# Altium schematic sheet [03] - CPU - DDR3, DDR3 MEM.SchDoc("[03] - CPU - DDR3, DDR3 MEM")
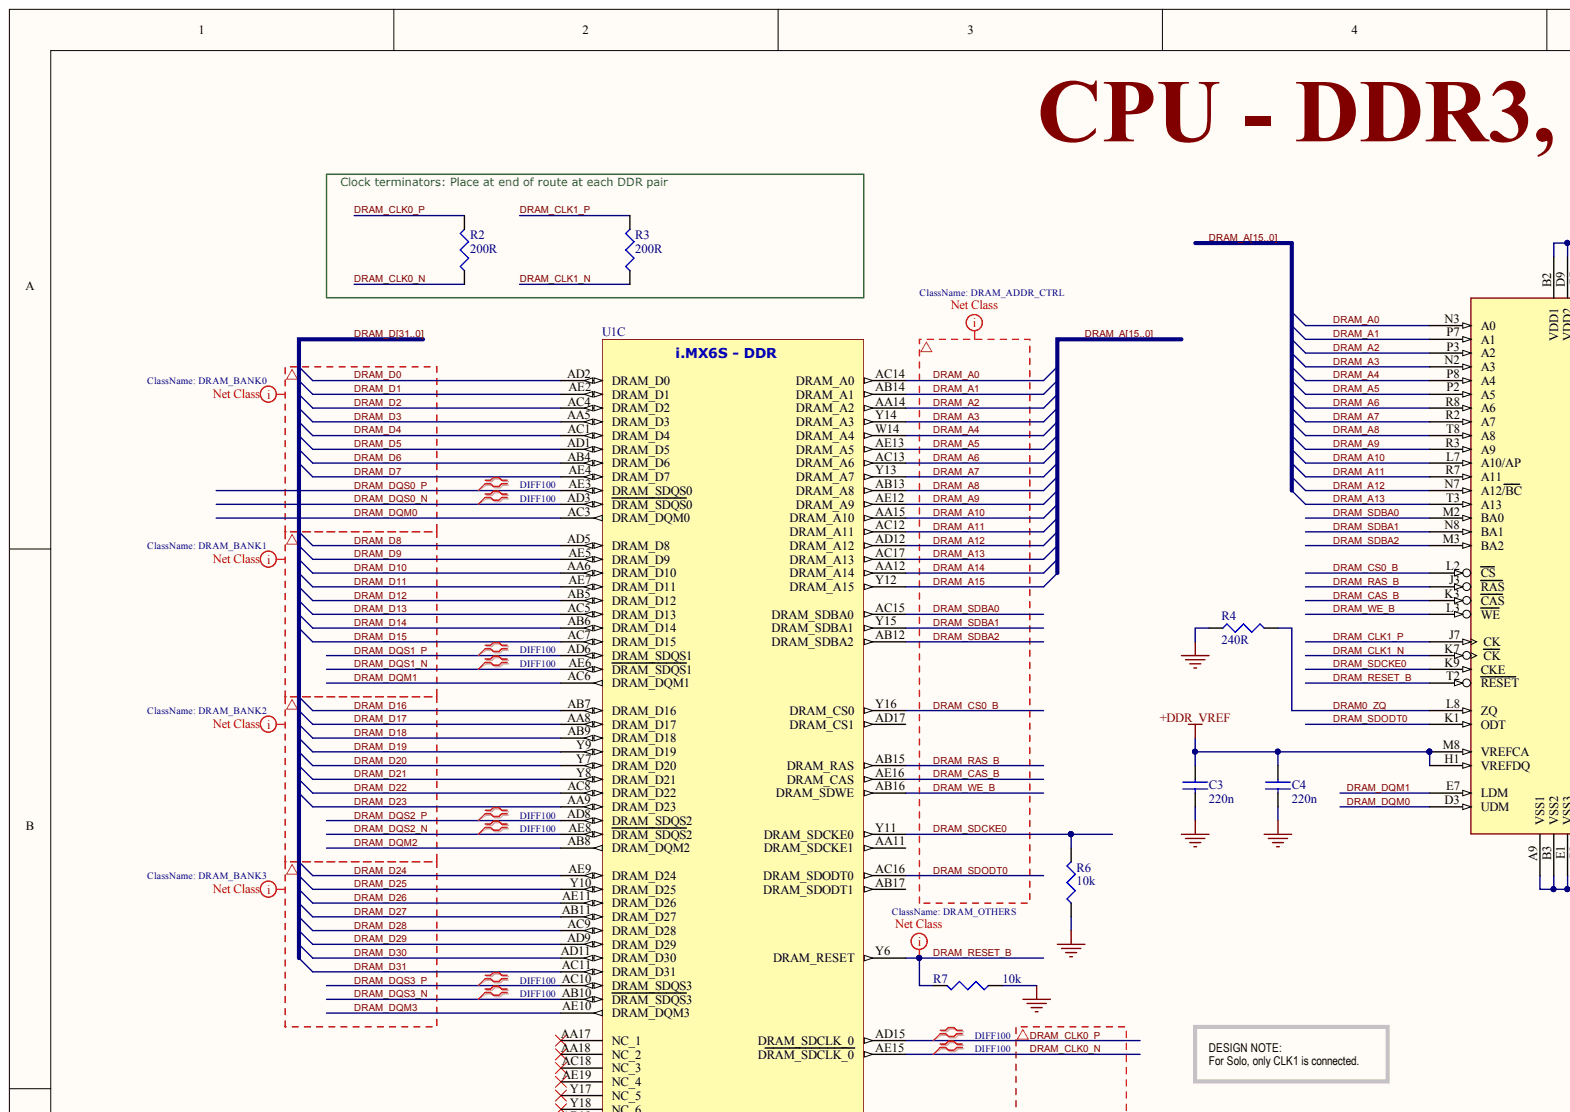
[diagram p0: [03] - CPU - DDR3, DDR3 MEM.SchDoc, top left]
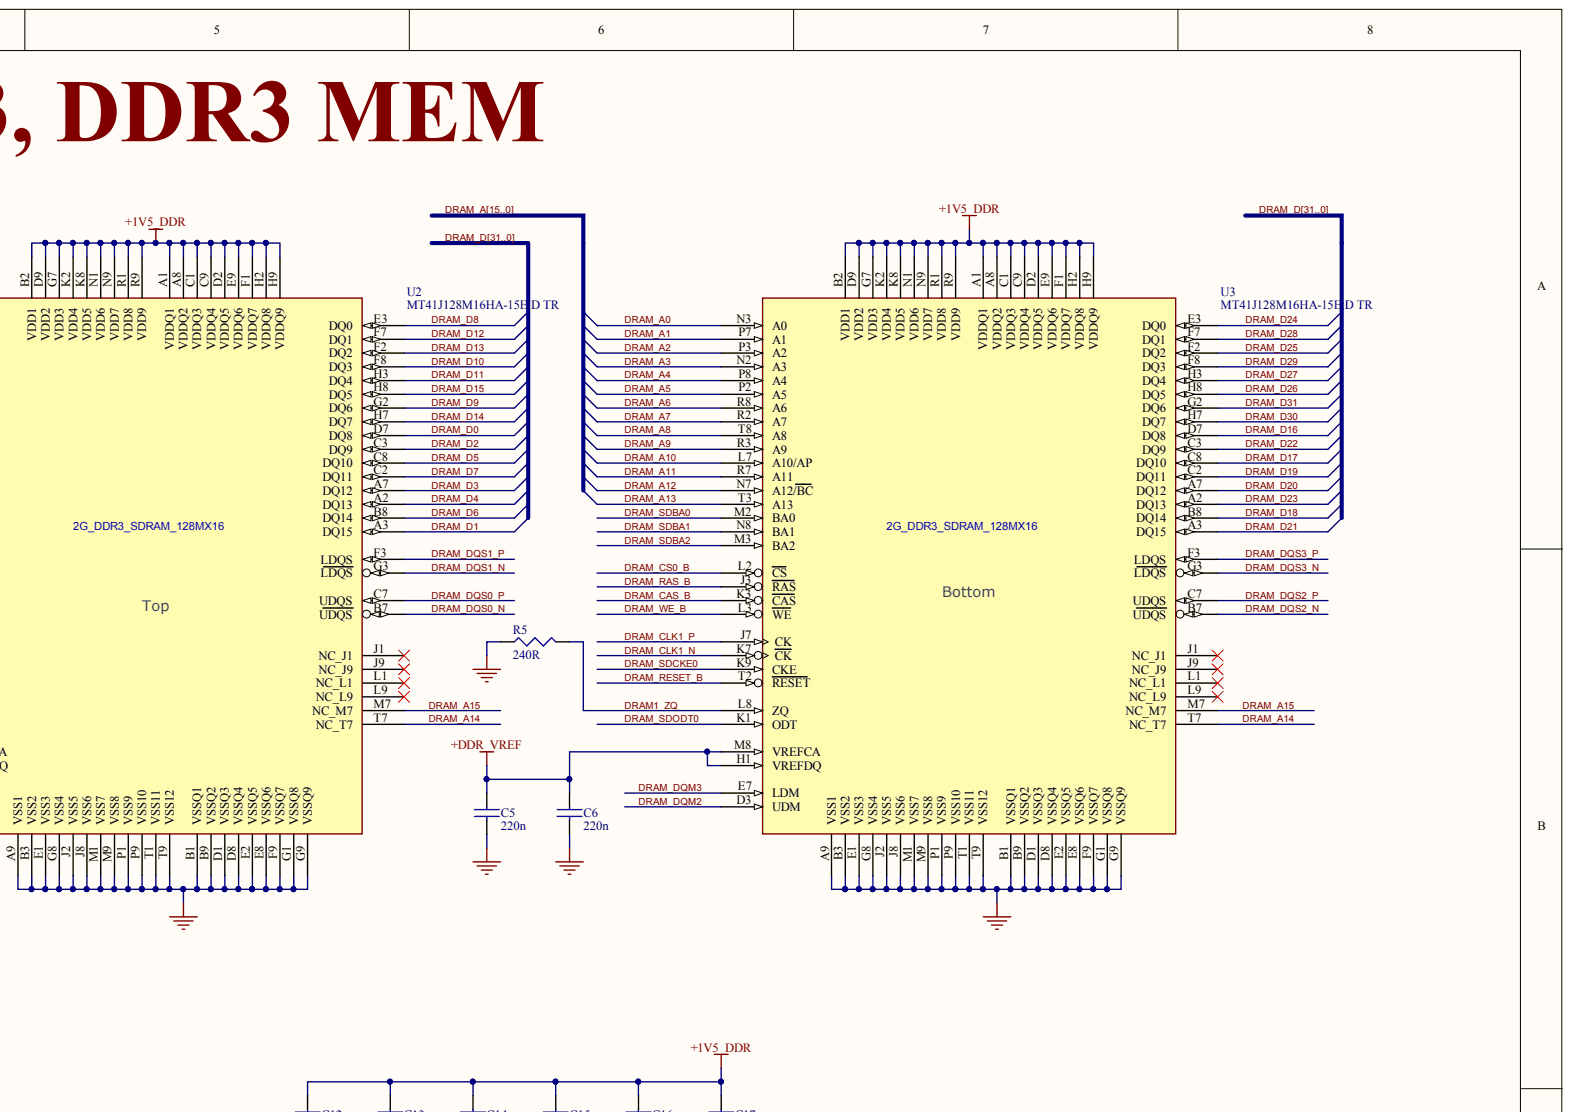
[diagram p1: [03] - CPU - DDR3, DDR3 MEM.SchDoc, top right]
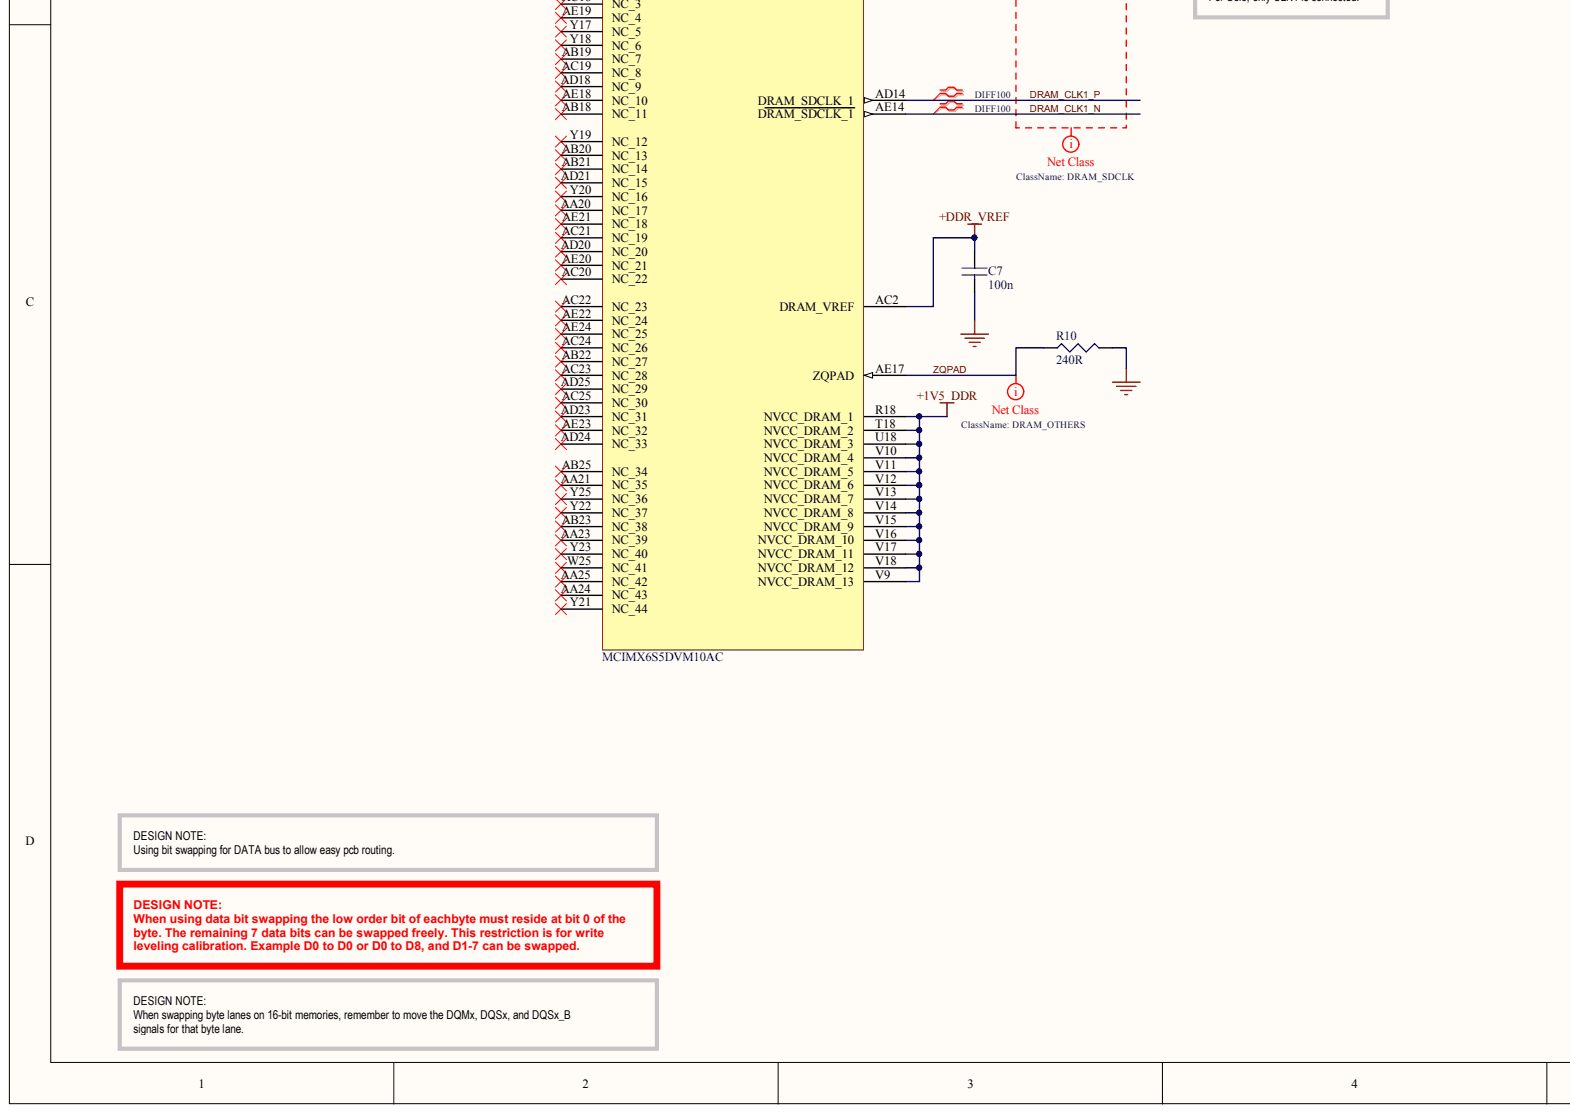
[diagram p2: [03] - CPU - DDR3, DDR3 MEM.SchDoc, bottom left]
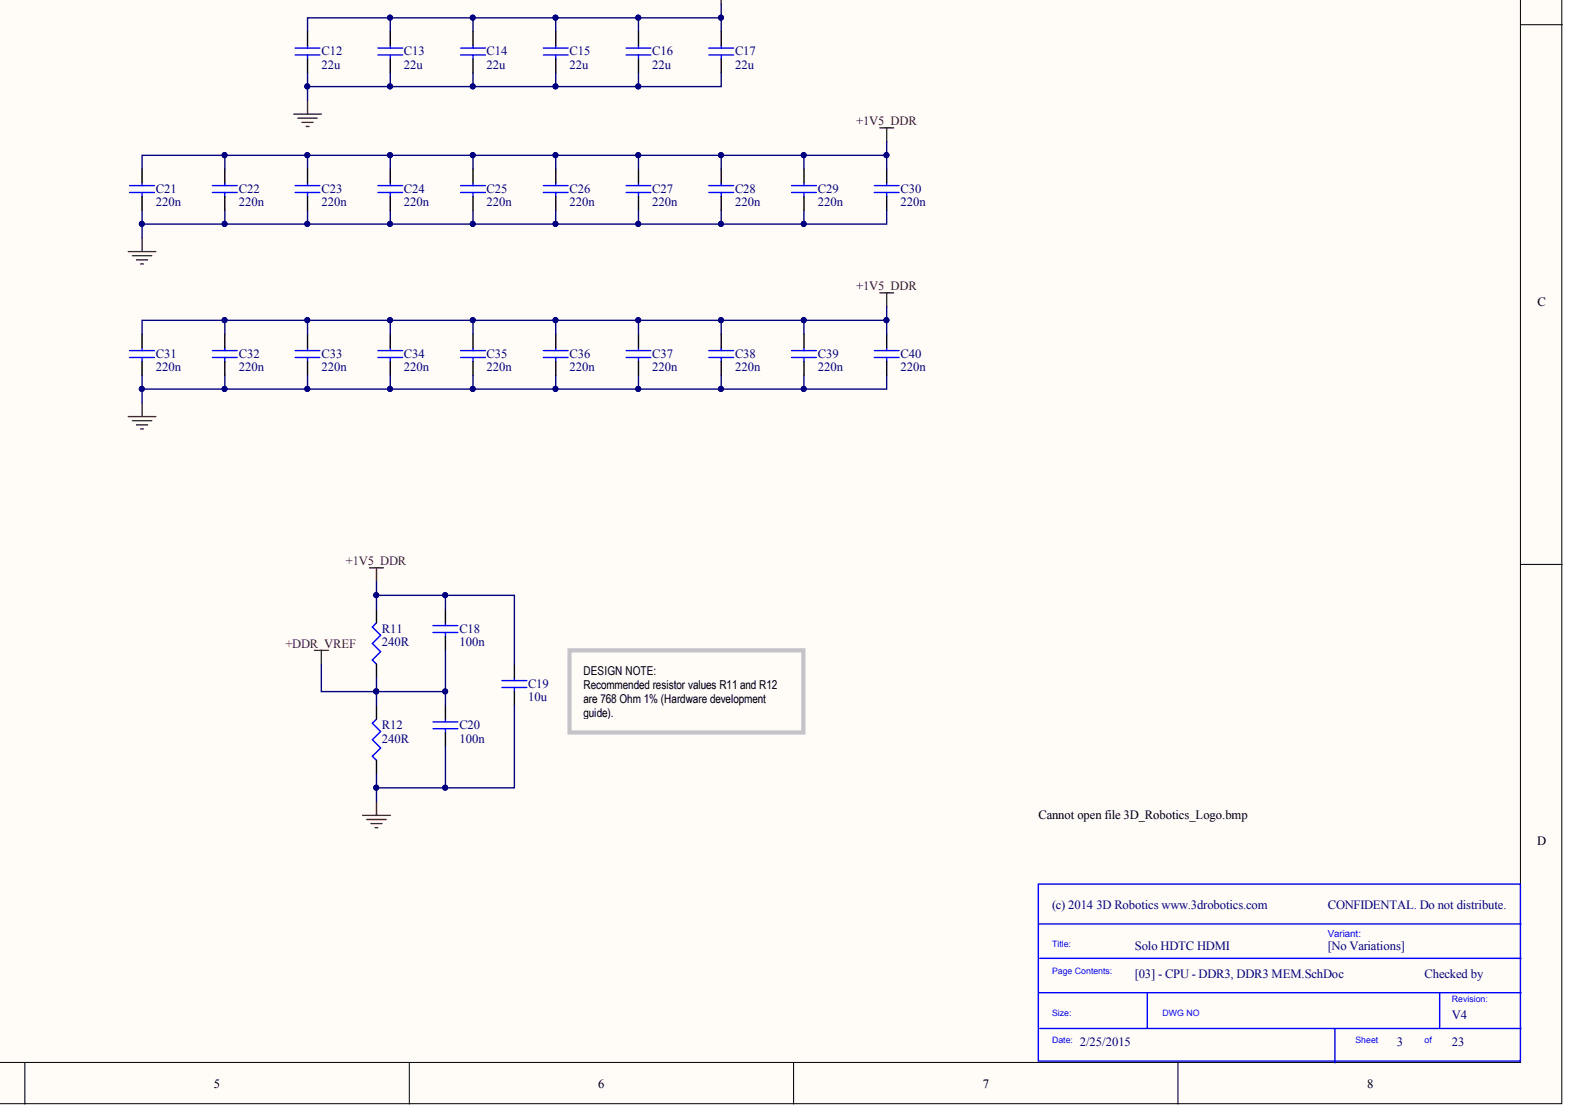
[diagram p3: [03] - CPU - DDR3, DDR3 MEM.SchDoc, bottom right]

## Parts
C3  220n
C4  220n
C5  220n
C6  220n
C7  100n
C12  22u
C13  22u
C14  22u
C15  22u
C16  22u
C17  22u
C18  100n
C19  10u
C20  100n
C21  220n
C22  220n
C23  220n
C24  220n
C25  220n
C26  220n
C27  220n
C28  220n
C29  220n
C30  220n
C31  220n
C32  220n
C33  220n
C34  220n
C35  220n
C36  220n
C37  220n
C38  220n
C39  220n
C40  220n
R2  200R
R3  200R
R4  240R
R5  240R
R6  10k
R7  10k
R10  240R
R11  240R
R12  240R
U1  MCIMX6S5DVM10AC  (part C)
U2  MT41J128M16HA-15E:D TR
U3  MT41J128M16HA-15E:D TR

## Nets
+1V5_DDR: C12.1 C13.1 C14.1 C15.1 C16.1 C17.1 C18.1 C19.1 C21.1 C22.1 C23.1 C24.1 C25.1 C26.1 C27.1 C28.1 C29.1 C30.1 C31.1 C32.1 C33.1 C34.1 C35.1 C36.1 C37.1 C38.1 C39.1 C40.1 R11.2 U1.R18(NVCC_DRAM_1) U1.T18(NVCC_DRAM_2) U1.U18(NVCC_DRAM_3) U1.V9(NVCC_DRAM_13) U1.V10(NVCC_DRAM_4) U1.V11(NVCC_DRAM_5) U1.V12(NVCC_DRAM_6) U1.V13(NVCC_DRAM_7) U1.V14(NVCC_DRAM_8) U1.V15(NVCC_DRAM_9) U1.V16(NVCC_DRAM_10) U1.V17(NVCC_DRAM_11) U1.V18(NVCC_DRAM_12) U2.A1(VDDQ1) U2.A8(VDDQ2) U2.B2(VDD1) U2.C1(VDDQ3) U2.C9(VDDQ4) U2.D2(VDDQ5) U2.D9(VDD2) U2.E9(VDDQ6) U2.F1(VDDQ7) U2.G7(VDD3) U2.H2(VDDQ8) U2.H9(VDDQ9) U2.K2(VDD4) U2.K8(VDD5) U2.N1(VDD6) U2.N9(VDD7) U2.R1(VDD8) U2.R9(VDD9) U3.A1(VDDQ1) U3.A8(VDDQ2) U3.B2(VDD1) U3.C1(VDDQ3) U3.C9(VDDQ4) U3.D2(VDDQ5) U3.D9(VDD2) U3.E9(VDDQ6) U3.F1(VDDQ7) U3.G7(VDD3) U3.H2(VDDQ8) U3.H9(VDDQ9) U3.K2(VDD4) U3.K8(VDD5) U3.N1(VDD6) U3.N9(VDD7) U3.R1(VDD8) U3.R9(VDD9)
+DDR_VREF: C3.1 C4.1 C5.1 C6.1 C7.1 C18.2 C20.1 R11.1 R12.2 U1.AC2(DRAM_VREF) U2.H1(VREFDQ) U2.M8(VREFCA) U3.H1(VREFDQ) U3.M8(VREFCA)
GND: C3.2 C4.2 C5.2 C6.2 C7.2 C12.2 C13.2 C14.2 C15.2 C16.2 C17.2 C19.2 C20.2 C21.2 C22.2 C23.2 C24.2 C25.2 C26.2 C27.2 C28.2 C29.2 C30.2 C31.2 C32.2 C33.2 C34.2 C35.2 C36.2 C37.2 C38.2 C39.2 C40.2 R4.1 R5.1 R6.1 R7.1 R10.2 R12.1 U2.A9(VSS1) U2.B1(VSSQ1) U2.B3(VSS2) U2.B9(VSSQ2) U2.D1(VSSQ3) U2.D8(VSSQ4) U2.E1(VSS3) U2.E2(VSSQ5) U2.E8(VSSQ6) U2.F9(VSSQ7) U2.G1(VSSQ8) U2.G8(VSS4) U2.G9(VSSQ9) U2.J2(VSS5) U2.J8(VSS6) U2.M1(VSS7) U2.M9(VSS8) U2.P1(VSS9) U2.P9(VSS10) U2.T1(VSS11) U2.T9(VSS12) U3.A9(VSS1) U3.B1(VSSQ1) U3.B3(VSS2) U3.B9(VSSQ2) U3.D1(VSSQ3) U3.D8(VSSQ4) U3.E1(VSS3) U3.E2(VSSQ5) U3.E8(VSSQ6) U3.F9(VSSQ7) U3.G1(VSSQ8) U3.G8(VSS4) U3.G9(VSSQ9) U3.J2(VSS5) U3.J8(VSS6) U3.M1(VSS7) U3.M9(VSS8) U3.P1(VSS9) U3.P9(VSS10) U3.T1(VSS11) U3.T9(VSS12)
DRAM0_ZQ: R4.2 U2.L8(ZQ)
DRAM1_ZQ: R5.2 U3.L8(ZQ)
DRAM_A0: U1.AC14(DRAM_A0) U2.N3(A0) U3.N3(A0)  [also labelled DRAM_A]
DRAM_A1: U1.AB14(DRAM_A1) U2.P7(A1) U3.P7(A1)  [also labelled DRAM_A]
DRAM_A2: U1.AA14(DRAM_A2) U2.P3(A2) U3.P3(A2)  [also labelled DRAM_A]
DRAM_A3: U1.Y14(DRAM_A3) U2.N2(A3) U3.N2(A3)  [also labelled DRAM_A]
DRAM_A4: U1.W14(DRAM_A4) U2.P8(A4) U3.P8(A4)  [also labelled DRAM_A]
DRAM_A5: U1.AE13(DRAM_A5) U2.P2(A5) U3.P2(A5)  [also labelled DRAM_A]
DRAM_A6: U1.AC13(DRAM_A6) U2.R8(A6) U3.R8(A6)  [also labelled DRAM_A]
DRAM_A7: U1.Y13(DRAM_A7) U2.R2(A7) U3.R2(A7)  [also labelled DRAM_A]
DRAM_A8: U1.AB13(DRAM_A8) U2.T8(A8) U3.T8(A8)  [also labelled DRAM_A]
DRAM_A9: U1.AE12(DRAM_A9) U2.R3(A9) U3.R3(A9)  [also labelled DRAM_A]
DRAM_A10: U1.AA15(DRAM_A10) U2.L7(A10/AP) U3.L7(A10/AP)  [also labelled DRAM_A]
DRAM_A11: U1.AC12(DRAM_A11) U2.R7(A11) U3.R7(A11)  [also labelled DRAM_A]
DRAM_A12: U1.AD12(DRAM_A12) U2.N7(A12/BC) U3.N7(A12/BC)  [also labelled DRAM_A]
DRAM_A13: U1.AC17(DRAM_A13) U2.T3(A13) U3.T3(A13)  [also labelled DRAM_A]
DRAM_A14: U1.AA12(DRAM_A14) U2.T7(NC_T7) U3.T7(NC_T7)  [also labelled DRAM_A]
DRAM_A15: U1.Y12(DRAM_A15) U2.M7(NC_M7) U3.M7(NC_M7)  [also labelled DRAM_A]
DRAM_CAS_B: U1.AE16(DRAM_CAS) U2.K3(CAS) U3.K3(CAS)
DRAM_CLK0_N: R2.1 U1.AE15(DRAM_SDCLK_0)
DRAM_CLK0_P: R2.2 U1.AD15(DRAM_SDCLK_0)
DRAM_CLK1_N: R3.1 U1.AE14(DRAM_SDCLK_1) U2.K7(CK) U3.K7(CK)
DRAM_CLK1_P: R3.2 U1.AD14(DRAM_SDCLK_1) U2.J7(CK) U3.J7(CK)
DRAM_CS0_B: U1.Y16(DRAM_CS0) U2.L2(CS) U3.L2(CS)
DRAM_D0: U1.AD2(DRAM_D0) U2.D7(DQ8)  [also labelled DRAM_D]
DRAM_D1: U1.AE2(DRAM_D1) U2.A3(DQ15)  [also labelled DRAM_D]
DRAM_D2: U1.AC4(DRAM_D2) U2.C3(DQ9)  [also labelled DRAM_D]
DRAM_D3: U1.AA5(DRAM_D3) U2.A7(DQ12)  [also labelled DRAM_D]
DRAM_D4: U1.AC1(DRAM_D4) U2.A2(DQ13)  [also labelled DRAM_D]
DRAM_D5: U1.AD1(DRAM_D5) U2.C8(DQ10)  [also labelled DRAM_D]
DRAM_D6: U1.AB4(DRAM_D6) U2.B8(DQ14)  [also labelled DRAM_D]
DRAM_D7: U1.AE4(DRAM_D7) U2.C2(DQ11)  [also labelled DRAM_D]
DRAM_D8: U1.AD5(DRAM_D8) U2.E3(DQ0)  [also labelled DRAM_D]
DRAM_D9: U1.AE5(DRAM_D9) U2.G2(DQ6)  [also labelled DRAM_D]
DRAM_D10: U1.AA6(DRAM_D10) U2.F8(DQ3)  [also labelled DRAM_D]
DRAM_D11: U1.AE7(DRAM_D11) U2.H3(DQ4)  [also labelled DRAM_D]
DRAM_D12: U1.AB5(DRAM_D12) U2.F7(DQ1)  [also labelled DRAM_D]
DRAM_D13: U1.AC5(DRAM_D13) U2.F2(DQ2)  [also labelled DRAM_D]
DRAM_D14: U1.AB6(DRAM_D14) U2.H7(DQ7)  [also labelled DRAM_D]
DRAM_D15: U1.AC7(DRAM_D15) U2.H8(DQ5)  [also labelled DRAM_D]
DRAM_D16: U1.AB7(DRAM_D16) U3.D7(DQ8)  [also labelled DRAM_D]
DRAM_D17: U1.AA8(DRAM_D17) U3.C8(DQ10)  [also labelled DRAM_D]
DRAM_D18: U1.AB9(DRAM_D18) U3.B8(DQ14)  [also labelled DRAM_D]
DRAM_D19: U1.Y9(DRAM_D19) U3.C2(DQ11)  [also labelled DRAM_D]
DRAM_D20: U1.Y7(DRAM_D20) U3.A7(DQ12)  [also labelled DRAM_D]
DRAM_D21: U1.Y8(DRAM_D21) U3.A3(DQ15)  [also labelled DRAM_D]
DRAM_D22: U1.AC8(DRAM_D22) U3.C3(DQ9)  [also labelled DRAM_D]
DRAM_D23: U1.AA9(DRAM_D23) U3.A2(DQ13)  [also labelled DRAM_D]
DRAM_D24: U1.AE9(DRAM_D24) U3.E3(DQ0)  [also labelled DRAM_D]
DRAM_D25: U1.Y10(DRAM_D25) U3.F2(DQ2)  [also labelled DRAM_D]
DRAM_D26: U1.AE11(DRAM_D26) U3.H8(DQ5)  [also labelled DRAM_D]
DRAM_D27: U1.AB11(DRAM_D27) U3.H3(DQ4)  [also labelled DRAM_D]
DRAM_D28: U1.AC9(DRAM_D28) U3.F7(DQ1)  [also labelled DRAM_D]
DRAM_D29: U1.AD9(DRAM_D29) U3.F8(DQ3)  [also labelled DRAM_D]
DRAM_D30: U1.AD11(DRAM_D30) U3.H7(DQ7)  [also labelled DRAM_D]
DRAM_D31: U1.AC11(DRAM_D31) U3.G2(DQ6)  [also labelled DRAM_D]
DRAM_DQM0: U1.AC3(DRAM_DQM0) U2.D3(UDM)
DRAM_DQM1: U1.AC6(DRAM_DQM1) U2.E7(LDM)
DRAM_DQM2: U1.AB8(DRAM_DQM2) U3.D3(UDM)
DRAM_DQM3: U1.AE10(DRAM_DQM3) U3.E7(LDM)
DRAM_DQS0_N: U1.AD3(DRAM_SDQS0) U2.B7(UDQS)
DRAM_DQS0_P: U1.AE3(DRAM_SDQS0) U2.C7(UDQS)
DRAM_DQS1_N: U1.AE6(DRAM_SDQS1) U2.G3(LDQS)
DRAM_DQS1_P: U1.AD6(DRAM_SDQS1) U2.F3(LDQS)
DRAM_DQS2_N: U1.AE8(DRAM_SDQS2) U3.B7(UDQS)
DRAM_DQS2_P: U1.AD8(DRAM_SDQS2) U3.C7(UDQS)
DRAM_DQS3_N: U1.AB10(DRAM_SDQS3) U3.G3(LDQS)
DRAM_DQS3_P: U1.AC10(DRAM_SDQS3) U3.F3(LDQS)
DRAM_RAS_B: U1.AB15(DRAM_RAS) U2.J3(RAS) U3.J3(RAS)
DRAM_RESET_B: R7.2 U1.Y6(DRAM_RESET) U2.T2(RESET) U3.T2(RESET)
DRAM_SDBA0: U1.AC15(DRAM_SDBA0) U2.M2(BA0) U3.M2(BA0)
DRAM_SDBA1: U1.Y15(DRAM_SDBA1) U2.N8(BA1) U3.N8(BA1)
DRAM_SDBA2: U1.AB12(DRAM_SDBA2) U2.M3(BA2) U3.M3(BA2)
DRAM_SDCKE0: R6.2 U1.Y11(DRAM_SDCKE0) U2.K9(CKE) U3.K9(CKE)
DRAM_SDODT0: U1.AC16(DRAM_SDODT0) U2.K1(ODT) U3.K1(ODT)
DRAM_WE_B: U1.AB16(DRAM_SDWE) U2.L3(WE) U3.L3(WE)
ZQPAD: R10.1 U1.AE17(ZQPAD)
N00135:
N00136:
N00137:
N00138:
N00139:
N00140:

Not connected: U1 AA11(DRAM_SDCKE1) AA17(NC_1) AA18(NC_2) AA20(NC_17) AA21(NC_35) AA23(NC_39) AA24(NC_43) AA25(NC_42) AB17(DRAM_SDODT1) AB18(NC_11) AB19(NC_7) AB20(NC_13) AB21(NC_14) AB22(NC_27) AB23(NC_38) AB25(NC_34) AC18(NC_3) AC19(NC_8) AC20(NC_22) AC21(NC_19) AC22(NC_23) AC23(NC_28) AC24(NC_26) AC25(NC_30) AD17(DRAM_CS1) AD18(NC_9) AD20(NC_20) AD21(NC_15) AD23(NC_31) AD24(NC_33) AD25(NC_29) AE18(NC_10) AE19(NC_4) AE20(NC_21) AE21(NC_18) AE22(NC_24) AE23(NC_32) AE24(NC_25) W25(NC_41) Y17(NC_5) Y18(NC_6) Y19(NC_12) Y20(NC_16) Y21(NC_44) Y22(NC_37) Y23(NC_40) Y25(NC_36); U2 J1(NC_J1) J9(NC_J9) L1(NC_L1) L9(NC_L9); U3 J1(NC_J1) J9(NC_J9) L1(NC_L1) L9(NC_L9)

## Library data of the parts
C3-C6 C21-C40 = (no symbol name): Manufacturer: TDK Corporation; Manufacturer Part Number: C1005X5R1E224M; Capacitance: 0.22µF; Value: 220nF; .Checked PCB: used; Voltage - Rated: 25V; Tolerance: ±20%; Temperature Coefficient: X5R; Category: Capacitors; Supplier Part Number: 445-5945-1-ND; Operating Temperature: -55°C ~ 85°C; Package / Case: 0402 (1005 Metric); Price 1k [$]: 0.02600; .Checked SCH: used; Supplier: Digikey; Supplier 1: Digi-Key; Supplier Part Number 1: 445-5945-1-ND; RoHS: RoHS Compliant; Stock 1: 54593; Pricing 1: 1=0.3, 10=0.2, 100=0.08, 250=0.05752, 500=0.041, 1000=0.03 (USD); Mounting Type: Surface Mount, MLCC; Applications: General Purpose; Ratings: -; Size / Dimension: 0.039" L x 0.020" W (1.00mm x 0.50mm); Height - Seated (Max): -; Thickness (Max): 0.022" (0.55mm); Lead Spacing: -; Features: -; Packaging: Cut Tape (CT); Lead Style: -; ComponentLink1URL: http://www.tdk.co.jp/tefe02/e412_c.pdf; ComponentLink1Description: http://www.tdk.co.jp/tefe02/e412_c.pdf
C7 C18 C20 = (no symbol name): Manufacturer: TDK Corporation; Manufacturer Part Number: C1005X5R1H104K; Capacitance: 0.1µF; Value: 100nF; .Checked PCB: used; Voltage - Rated: 50V; Tolerance: ±10%; Temperature Coefficient: X5R; Category: Capacitors; Supplier Part Number: 445-5942-1-ND; Operating Temperature: -55°C ~ 85°C; Package / Case: 0402 (1005 Metric); Price 1k [$]: 0.02340; .Checked SCH: used; Supplier: Digikey; Supplier 1: Digi-Key; Supplier Part Number 1: 445-5942-1-ND; RoHS: RoHS Compliant; Stock 1: 106926; Pricing 1: 1=0.27, 10=0.18, 100=0.072, 250=0.05176, 500=0.0369, 1000=0.027 (USD); Mounting Type: Surface Mount, MLCC; Applications: General Purpose; Ratings: -; Size / Dimension: 0.039" L x 0.020" W (1.00mm x 0.50mm); Height - Seated (Max): -; Thickness (Max): 0.022" (0.55mm); Lead Spacing: -; Features: -; Packaging: Cut Tape (CT); Lead Style: -; ComponentLink1URL: http://www.tdk.co.jp/tefe02/e412_c.pdf; ComponentLink1Description: http://www.tdk.co.jp/tefe02/e412_c.pdf
C12-C17 = (no symbol name): Manufacturer: Murata Electronics North America; Manufacturer Part Number: GRM188R60G226MEA0L; Capacitance: 22µF; Voltage - Rated: 4V; Tolerance: ±20%; Temperature Coefficient: X5R; .Checked PCB: RF, used by MM; .Checked SCH: MM, checked by RF; .Creator: MM; Supplier 1: Digi-Key; Supplier Part Number 1: 490-5526-1-ND; RoHS: RoHS Compliant; Category: Capacitors; Stock 1: 5760; Pricing 1: 1=0.79, 10=0.663, 50=0.4752, 100=0.4158, 250=0.32672, 500=0.297, 1000=0.2376 (USD); Mounting Type: Surface Mount, MLCC; Operating Temperature: -55°C ~ 85°C; Applications: General Purpose; Ratings: -; Package / Case: 0603 (1608 Metric); Size / Dimension: 0.063" L x 0.031" W (1.60mm x 0.80mm); Height - Seated (Max): -; Thickness (Max): 0.035" (0.90mm); Lead Spacing: -; Features: -; Packaging: Cut Tape (CT); Lead Style: -; ComponentLink1URL: http://www.murata.com/products/catalog/pdf/c02e.pdf; ComponentLink1Description: http://www.murata.com/products/catalog/pdf/c02e.pdf
C19 = (no symbol name): Manufacturer: TDK Corporation; Manufacturer Part Number: C1608X5R0J106M; Capacitance: 10µF; Value: 10µF; .Checked PCB: used; Voltage - Rated: 6.3V; Tolerance: ±20%; Temperature Coefficient: X5R; Category: Capacitors; Supplier Part Number: 445-4112-1-ND; Operating Temperature: -55°C ~ 85°C; Package / Case: 0603 (1608 Metric); Price 1k [$]: 0.08580; .Checked SCH: used; Features: Low ESR; Supplier: Digikey; Supplier 1: Digi-Key; Supplier Part Number 1: 445-4112-1-ND; RoHS: RoHS Compliant; Stock 1: 48157; Pricing 1: 1=0.46, 10=0.363, 100=0.1898, 250=0.14024, 500=0.1089, 1000=0.0858 (USD); Mounting Type: Surface Mount, MLCC; Applications: General Purpose; Ratings: -; Size / Dimension: 0.063" L x 0.031" W (1.60mm x 0.80mm); Height - Seated (Max): -; Thickness (Max): 0.037" (0.95mm); Lead Spacing: -; Packaging: Cut Tape (CT); Lead Style: -; ComponentLink1URL: http://www.tdk.com/pdf/general_B11.pdf; ComponentLink1Description: http://www.tdk.com/pdf/general_B11.pdf
R2 R3 = (no symbol name): Manufacturer: Vishay Dale; Manufacturer Part Number: CRCW0402200RFKED; Resistance (Ohms): 200; Power (Watts): 0.063W, 1/16W; Temperature Coefficient: ±100ppm/°C; Tolerance: ±1%; .Checked PCB: RF, used by MM; .Checked SCH: MM, checked by RF; .Creator: MM; Supplier 1: Digi-Key; RoHS: RoHS Compliant; Category: Resistors; Stock 1: 251574; Pricing 1: 10=0.083, 50=0.0444, 200=0.0255, 1000=0.0174 (USD); Composition: Thick Film; Features: Automotive AEC-Q200 Qualified; Package / Case: 0402 (1005 Metric); Supplier Device Package: 0402 (1005 Metric); Size / Dimension: 0.039" L x 0.020" W (1.00mm x 0.50mm); Height: 0.016" (0.40mm); Number of Terminations: 2; Packaging: Cut Tape (CT); ComponentLink1URL: http://www.vishay.com/doc?20035; ComponentLink1Description: http://www.vishay.com/doc?20035; Supplier Part Number 1: 541-200LCT-ND
R4 R5 R10-R12 = (no symbol name): Manufacturer: Stackpole Electronics Inc; Manufacturer Part Number: RMCF0402FT240R; Resistance (Ohms): 240; Power (Watts): 0.063W, 1/16W; Tolerance: ±1%; .Checked PCB: used; Temperature Coefficient: ±100ppm/°C; Category: Resistors; Supplier Part Number: RMCF0402FT240RCT-ND; Package / Case: 0402 (1005 Metric); Price 1k [$]: 0.00384; Value: 240R; .Checked SCH: used; Supplier: Digikey; Supplier 1: Digi-Key; Supplier Part Number 1: RMCF0402FT240RCT-ND; RoHS: RoHS Compliant; Stock 1: 39860; Pricing 1: 1=0.04, 10=0.025, 50=0.0138, 100=0.0105, 250=0.0078, 500=0.00576, 1000=0.00384 (USD); Composition: Thick Film; Features: -; Size / Dimension: 0.039" L x 0.020" W (1.00mm x 0.50mm); Height: 0.016" (0.40mm); Number of Terminations: 2; Packaging: Cut Tape (CT); ComponentLink1URL: http://www.seielect.com/Catalog/SEI-RMCF.pdf; ComponentLink1Description: http://www.seielect.com/Catalog/SEI-RMCF.pdf
R6 R7 = (no symbol name): Manufacturer: Yageo; Manufacturer Part Number: RC0402FR-0710KL; Power (Watts): 0.063W, 1/16W; Tolerance: ±1%; .Checked PCB: used; Temperature Coefficient: ±100ppm/°C; Category: Resistors; Supplier Part Number: 311-10.0KLRCT-ND; Package / Case: 0402 (1005 Metric); Price 1k [$]: 0.01421; Resistance (Ohms): 10K; Value: 10k; .Checked SCH: used; Supplier: Digikey; Supplier 1: Digi-Key; Supplier Part Number 1: 311-10.0KLRCT-ND; RoHS: RoHS Compliant; Stock 1: 2916495; Pricing 1: 10=0.014, 50=0.0104, 100=0.0079, 250=0.00584, 500=0.00432, 1000=0.00288 (USD); Composition: Thick Film; Features: -; Size / Dimension: 0.039" L x 0.020" W (1.00mm x 0.50mm); Height: 0.016" (0.40mm); Number of Terminations: 2; Packaging: Cut Tape (CT); ComponentLink1URL: http://www.yageo.com/documents/recent/PYu-RC0402_51_RoHS_L_6.pdf; ComponentLink1Description: http://www.yageo.com/documents/recent/PYu-RC0402_51_RoHS_L_6.pdf
U1 = (no symbol name): Manufacturer: Freescale Semiconductor; Processor Type: ARM® Cortex™-A9, 32-Bit Single-Core; Speed: 1.0GHz; Voltage: 1.05 V ~ 1.5 V; .Creator: MM; Supplier 1: Digi-Key; Manufacturer Part Number: MCIMX6S5DVM10AC; RoHS: RoHS Compliant; Category: Integrated Circuits (ICs); Stock 1: 0; Pricing 1: 60=18.458 (USD); Packaging: Tray; Features: -; Mounting Type: *; Package / Case: 624-LFBGA; Supplier Device Package: *; ComponentLink1URL: http://cache.freescale.com/files/32bit/doc/data_sheet/IMX6SDLCEC.pdf; ComponentLink1Description: http://cache.freescale.com/files/32bit/doc/data_sheet/IMX6SDLCEC.pdf; Supplier Part Number 1: MCIMX6S5DVM10AC-ND
U2 U3 = (no symbol name): Manufacturer: Micron Technology Inc; Format - Memory: RAM; Memory Type: DDR3 SDRAM; Memory Size: 2G (128M x 16); Speed: 667MHz; Interface: Parallel; Voltage - Supply: 1.425 V ~ 1.575 V; .Creator: MM; .Checked PCB: RF, used by MM; .Checked SCH: MM, checked by RF; Supplier 1: Digi-Key; Manufacturer Part Number: MT41J128M16HA-15E:D TR; RoHS: RoHS Compliant; Category: Integrated Circuits (ICs); Stock 1: 9228; Pricing 1: 1=11.4, 10=10.557, 25=10.3172, 50=10.26, 100=9.0354, 250=8.58332, 500=8.49746 (USD); Operating Temperature: 0°C ~ 95°C; Package / Case: 96-TFBGA; Supplier Device Package: 96-FBGA (9x14); Packaging: Cut Tape (CT); ComponentLink1URL: http://www.micron.com/~/media/Documents/Products/Data%20Sheet/DRAM/2Gb_DDR3_SDRAM.pdf; ComponentLink1Description: http://www.micron.com/~/media/Documents/Products/Data%20Sheet/DRAM/2Gb_DDR3_SDRAM.pdf; Supplier Part Number 1: 557-1493-1-ND
